# Altium schematic sheet pwm_outputs.SchDoc
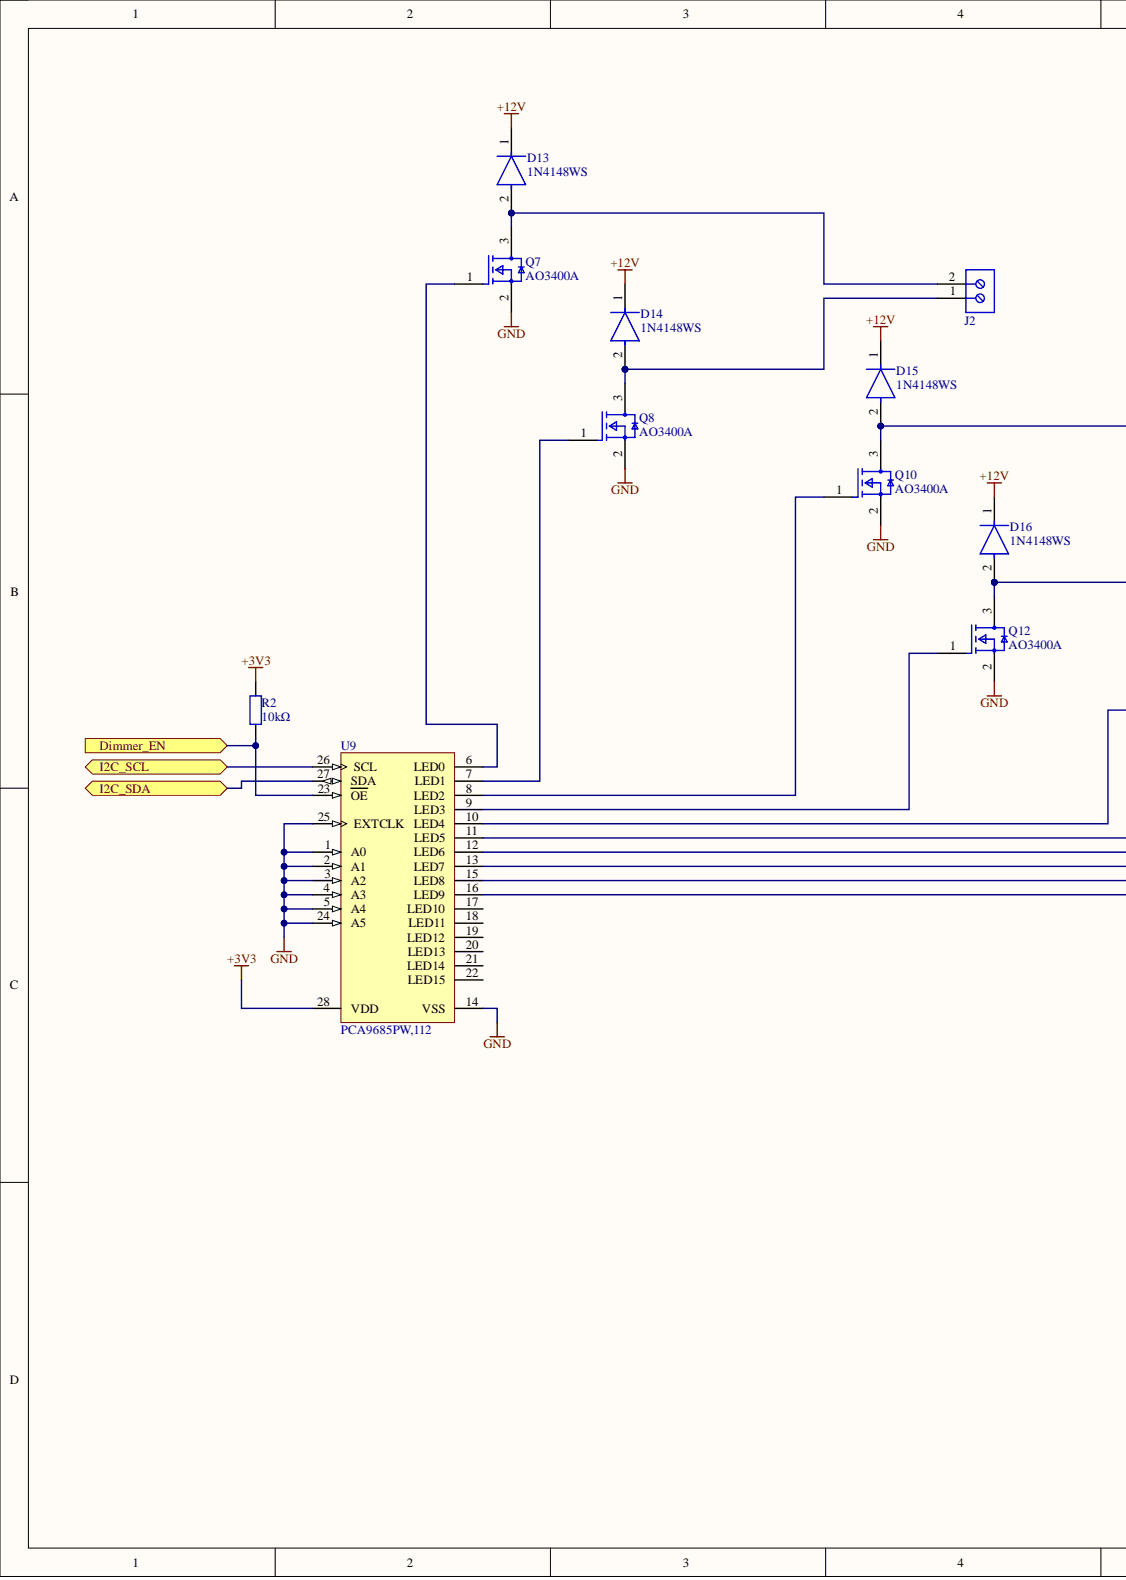
[diagram p0: pwm_outputs.SchDoc, left]
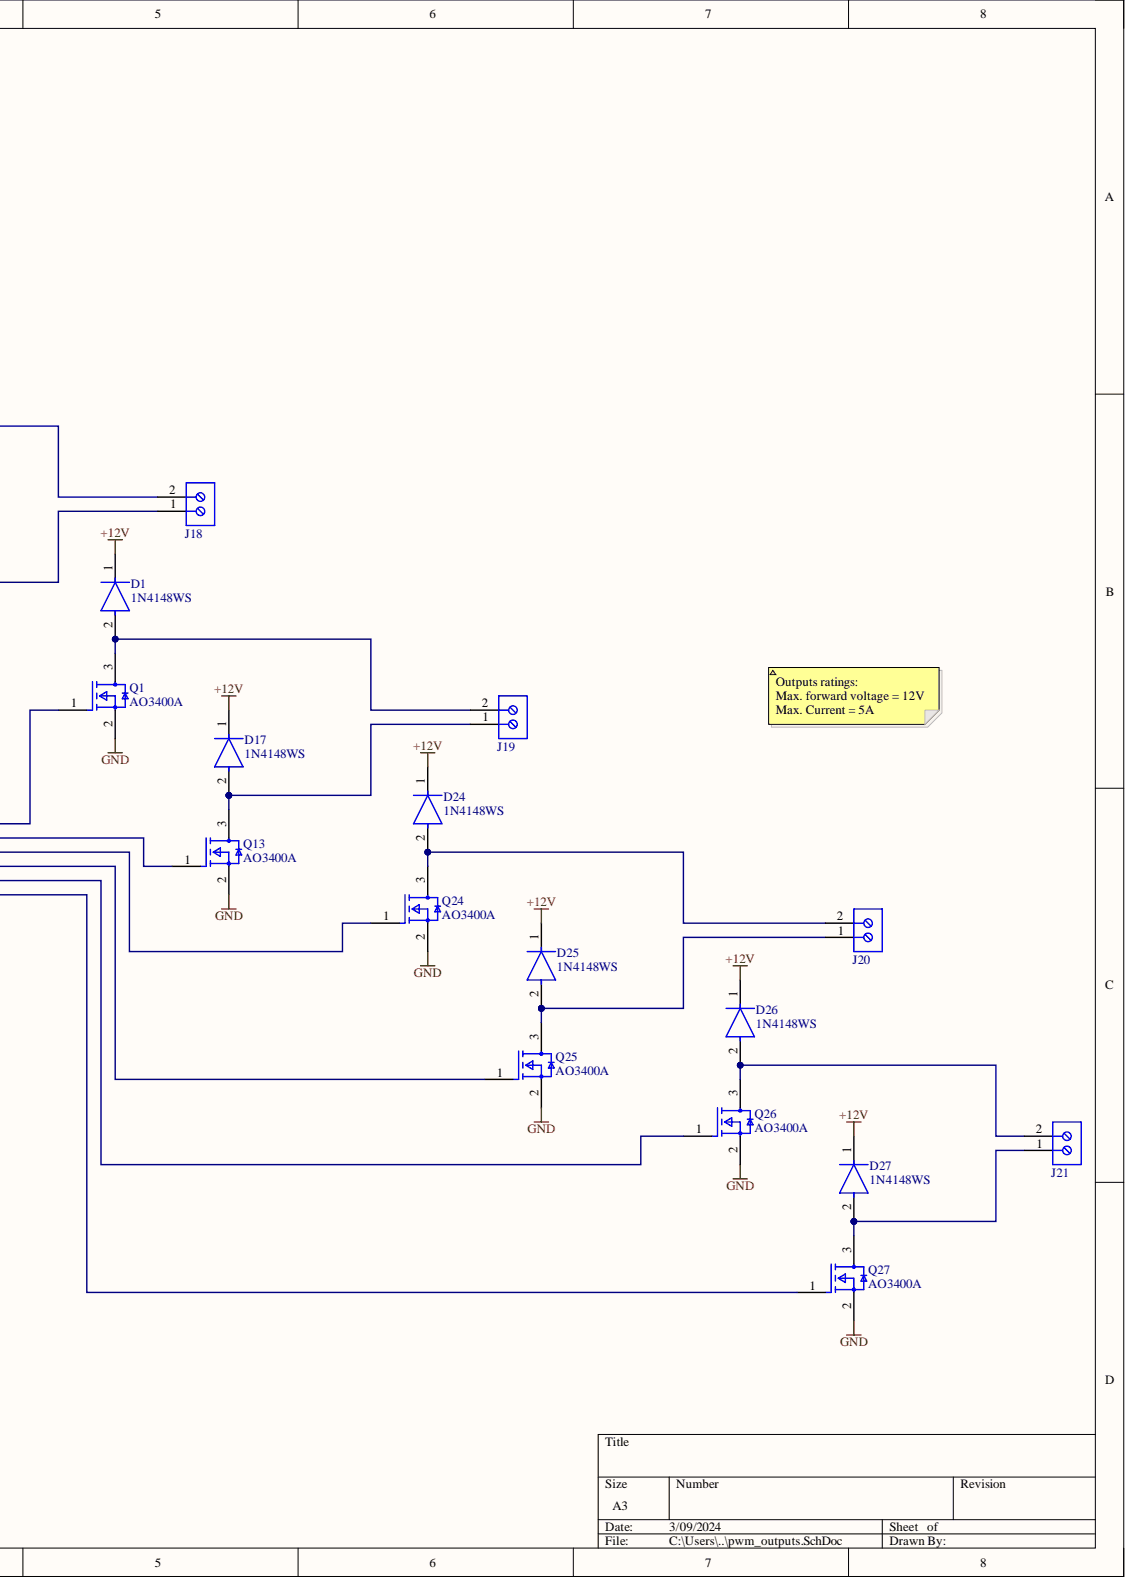
[diagram p1: pwm_outputs.SchDoc, right]

## Parts
D1  1N4148WS  [FP-477AB-IPC_C]
D13  1N4148WS  [FP-477AB-IPC_C]
D14  1N4148WS  [FP-477AB-IPC_C]
D15  1N4148WS  [FP-477AB-IPC_C]
D16  1N4148WS  [FP-477AB-IPC_C]
D17  1N4148WS  [FP-477AB-IPC_C]
D24  1N4148WS  [FP-477AB-IPC_C]
D25  1N4148WS  [FP-477AB-IPC_C]
D26  1N4148WS  [FP-477AB-IPC_C]
D27  1N4148WS  [FP-477AB-IPC_C]
J2  282836-2  [TECO-282836-2_V]  (value not drawn)
J18  282836-2  [TECO-282836-2_V]  (value not drawn)
J19  282836-2  [TECO-282836-2_V]  (value not drawn)
J20  282836-2  [TECO-282836-2_V]  (value not drawn)
J21  282836-2  [TECO-282836-2_V]  (value not drawn)
Q1  AO3400A  [SOT-23]
Q7  AO3400A  [SOT-23]
Q8  AO3400A  [SOT-23]
Q10  AO3400A  [SOT-23]
Q12  AO3400A  [SOT-23]
Q13  AO3400A  [SOT-23]
Q24  AO3400A  [SOT-23]
Q25  AO3400A  [SOT-23]
Q26  AO3400A  [SOT-23]
Q27  AO3400A  [SOT-23]
R2  10kΩ  [RESC2013X50X30ML20T20]
U9  PCA9685PW,112  [SOT361-1_V]

## Ports
Dimmer_EN, I2C_SCL, I2C_SDA

## Nets
+3V3: R2.2 U9.28(VDD)
+12V: D1.1 D13.1 D14.1 D15.1 D16.1 D17.1 D24.1 D25.1 D26.1 D27.1
GND: Q1.2 Q7.2 Q8.2 Q10.2 Q12.2 Q13.2 Q24.2 Q25.2 Q26.2 Q27.2 U9.1(A0) U9.2(A1) U9.3(A2) U9.4(A3) U9.5(A4) U9.14(VSS) U9.24(A5) U9.25(EXTCLK)
Dimmer_EN: R2.1 U9.23(OE) ; port Dimmer_EN
I2C_SCL: U9.26(SCL) ; port I2C_SCL
I2C_SDA: U9.27(SDA) ; port I2C_SDA
NetD1_2: D1.2 J19.2 Q1.3
NetD13_2: D13.2 J2.2 Q7.3
NetD14_2: D14.2 J2.1 Q8.3
NetD15_2: D15.2 J18.2 Q10.3
NetD16_2: D16.2 J18.1 Q12.3
NetD17_2: D17.2 J19.1 Q13.3
NetD24_2: D24.2 J20.2 Q24.3
NetD25_2: D25.2 J20.1 Q25.3
NetD26_2: D26.2 J21.2 Q26.3
NetD27_2: D27.2 J21.1 Q27.3
NetQ1_1: Q1.1 U9.10(LED4)
NetQ7_1: Q7.1 U9.6(LED0)
NetQ8_1: Q8.1 U9.7(LED1)
NetQ10_1: Q10.1 U9.8(LED2)
NetQ12_1: Q12.1 U9.9(LED3)
NetQ13_1: Q13.1 U9.11(LED5)
NetQ24_1: Q24.1 U9.12(LED6)
NetQ25_1: Q25.1 U9.13(LED7)
NetQ26_1: Q26.1 U9.15(LED8)
NetQ27_1: Q27.1 U9.16(LED9)

Not connected: U9 17(LED10) 18(LED11) 19(LED12) 20(LED13) 21(LED14) 22(LED15)

## Library data of the parts
D1 D13-D17 D24-D27 = CMP-07163-000040-1: DIODE GEN PURP 75V 150MA SOD323F; Element Configuration: Single; Forward Voltage: 1V; Max Forward Surge Current (Ifsm): 2A; Peak Reverse Current: 5uA; Power Dissipation: 200mW; Recovery Time: 4ns; Average Rectified Current: 150mA; Component Kind: Standard; Contact Plating: Tin; Forward Current: 150mA; Height: 1mm; Lead Free: Lead Free; Length: 1.8mm; Library Name: Altium Content Vault; Max Operating Temperature: 150°C; Max Repetitive Reverse Voltage (Vrrm): 75V; Max Reverse Voltage (DC): 75V; Min Operating Temperature: -55°C; Mount: Surface Mount; Number of Pins: 2; Package Quantity: 1; Packaging: Tape and Reel; Radiation Hardening: No; REACH SVHC: No SVHC; Reverse Recovery Time: 4ns; Reverse Voltage: 75V; Weight: 0.0276g; Width: 1.35mm
J2 J18-J21 = CMP-1684-00017-1: Female Header, Pitch 5 mm, 1 x 2 Position, Height 10 mm, Tail Length 3.5 mm, Rohs, Bulk; Manufacturer: TE Connectivity; 3D Model: 282836-2.pdf; Clamp Material - Plating: Brass-NickelPlated; Current: 13.5A; Dynamic Catalog: BuchananSeries; Featured Product: EurostyleTerminalBlockConnectors; Mating Orientation: HorizontalwithBoard; Number of Levels: 1; Other Names: 5ULV-02B  A98076; Pitch: 0.197"(5.00mm); Positions Per Level: 2; Product Training Modules: Wire-to-BoardEurostyleTerminalBlocks; Screw Thread: M3; Torque - Screw: 0.68Nm(6Lb-In); Voltage: 300V; Wire Gauge: 16-30AWG; Wire Termination: Screw-RisingCageClamp; Category: Connectors,Interconnects; Color: Green; Component Kind: Standard; Contact Material - Plating: Brass-TinPlated; Datasheet URL: http://www.te.com.cn/commerce/DocumentDelivery/DDEController?Action=showdoc&DocId=Catalog+Section%7F1308389_EUROSTYLE_TERMINAL_BLOCKS%7F0607%7Fpdf%7FEnglish%7FENG_CS_1308389_EUROSTYLE_TERMINAL_BLOCKS_0607.pdf%7F282836-2; Family: TerminalBlocks-WiretoBoard; Features: Interlocking(Side); Housing Material: Polyamide(PA66),Nylon6/6; Library Name: Altium Content Vault; Manufacturer URL: http://www.te.com; Mounting Type: ThroughHole; Package Description: Female Header, Pitch 5 mm, 1 x 2 Position, Height 10 mm, Tail Length 3.5 mm; Package Reference: THD; Packaging: Bulk; RoHS Information: 282836-2StatementofCompliance; Screw Material - Plating: Brass-NickelPlated; Series: Buchanan; Standard Package: 300
Q1 Q7 Q8 Q10 Q12 Q13 Q24-Q27 = MOSFET_AO3400A: MOSFET N-CH 30V 5.7A SOT23; Gate to Source Voltage (Vgs): 12V; Input Capacitance: 630pF; Max Power Dissipation: 1.4W; Number of Elements: 1; Rds On Max: 26.5mR; Case/Package: SOT-23; Component Kind: Standard; Continuous Drain Current (ID): 5.7A; Drain to Source Voltage (Vdss): 30V; Lead Free: Lead Free; Library Name: integrated_library.IntLib; Max Operating Temperature: 150°C; Min Operating Temperature: -55°C; Mount: Surface Mount; Number of Pins: 3; Packaging: Tape and Reel; Power Dissipation: 1.4W; Radiation Hardening: No
R2 = Res_0805: Resistor; Component Kind: Standard; Library Name: PCB_Project.SchLib; Signal Integrity: Res; Simulation: RESISTOR
U9 = CMP-1595-00004-2: 16-Channel, 12-Bit PWM Fm+ I2C-Bus LED Controller, 2.3 to 5.5 V, -40 to 85 degC, 28-Pin TSSOP (SOT361-1), RoHS, Tape and Reel; Frequency: 25000000 Hz; Supply Current Max: 10 mA; Supply Voltage Max: 5.5 V; Supply Voltage Min: 2.3 V; Code_JEDEC: MO-153; Component Kind: Standard; ComponentLink1Description: Manufacturer URL; ComponentLink1URL: http://www.nxp.com/; ComponentLink2Description: Datasheet; ComponentLink2URL: http://www.nxp.com/documents/data_sheet/PCA9685.pdf; ComponentLink3Description: Package Specification; ComponentLink3URL: http://www.nxp.com/documents/outline_drawing/sot361-1_po.pdf; DatasheetVersion: Rev. 4; Library Name: Altium Content Vault; Mounting Technology: Surface Mount; PackageDescription: 28-Pin Small Outline Package, Body 9.7 x 4.4 mm, Pitch 0.65 mm; PackageVersion: 02/2003; Packing: Tape and Reel; PartNumber: PCA9685; RoHS: TRUE; Temperature Max: 85 degC; Temperature Min: -40 degC
